# Altium schematic sheet Flash.SchDoc(Flash)
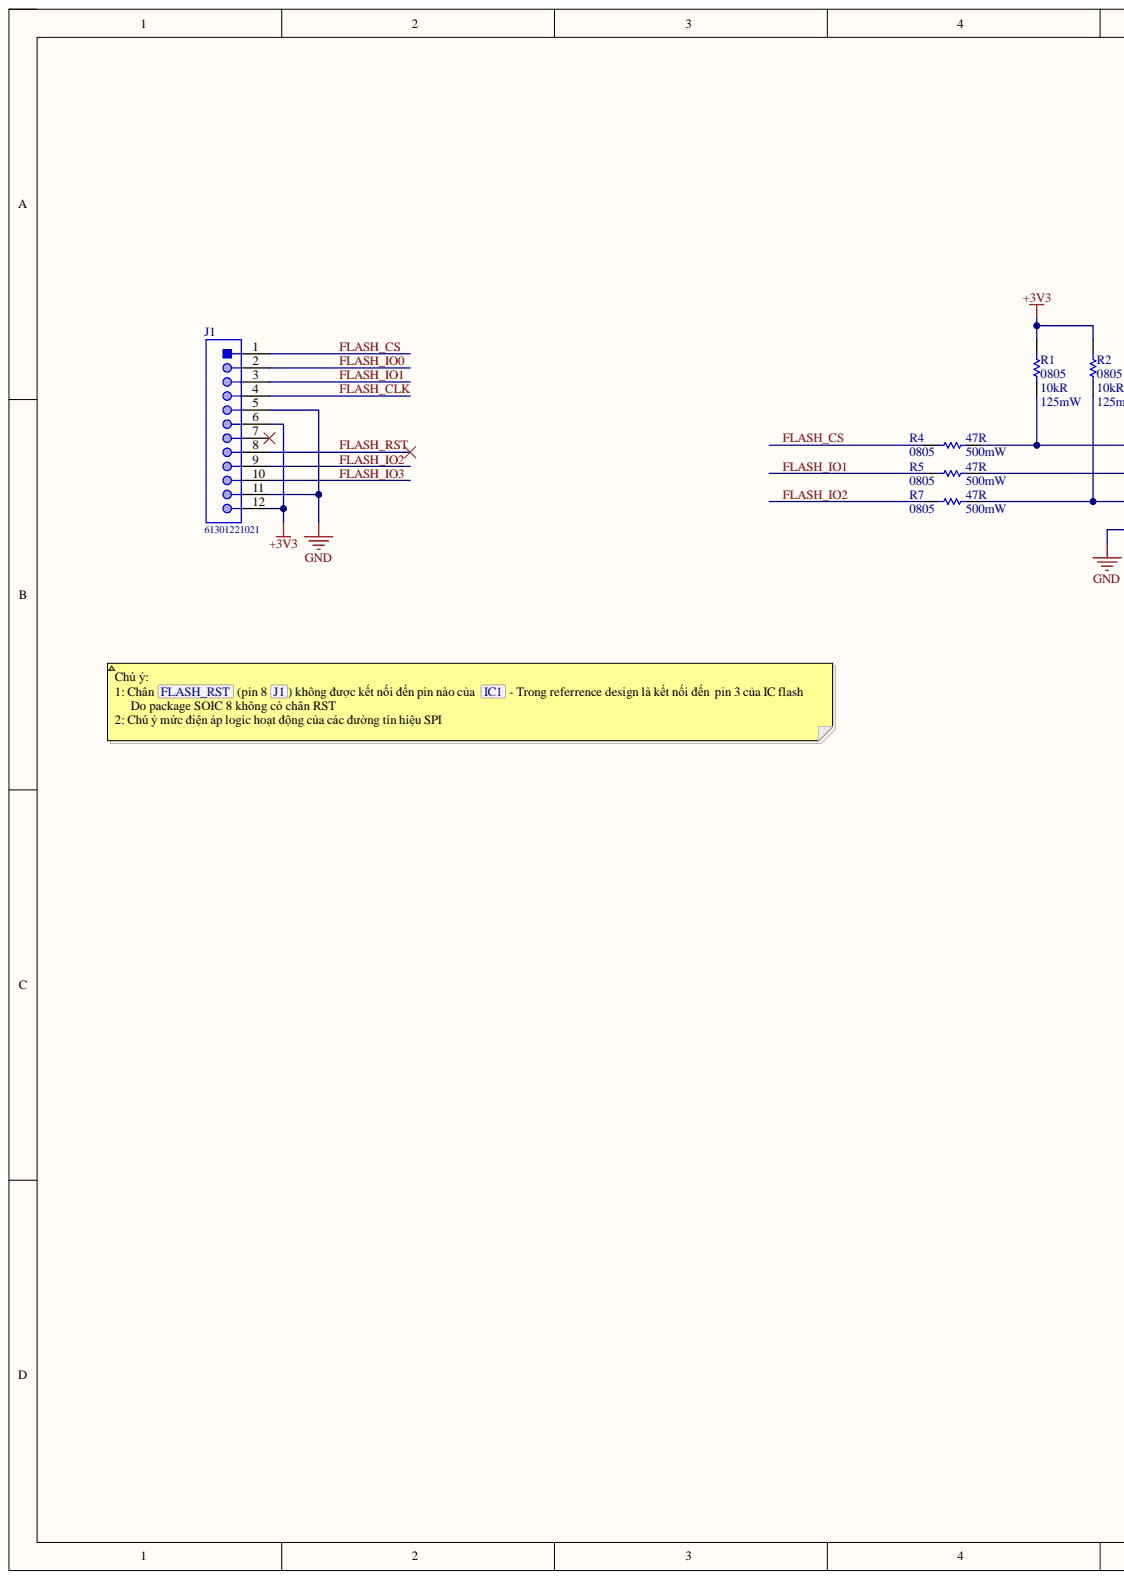
[diagram p0: Flash.SchDoc, left]
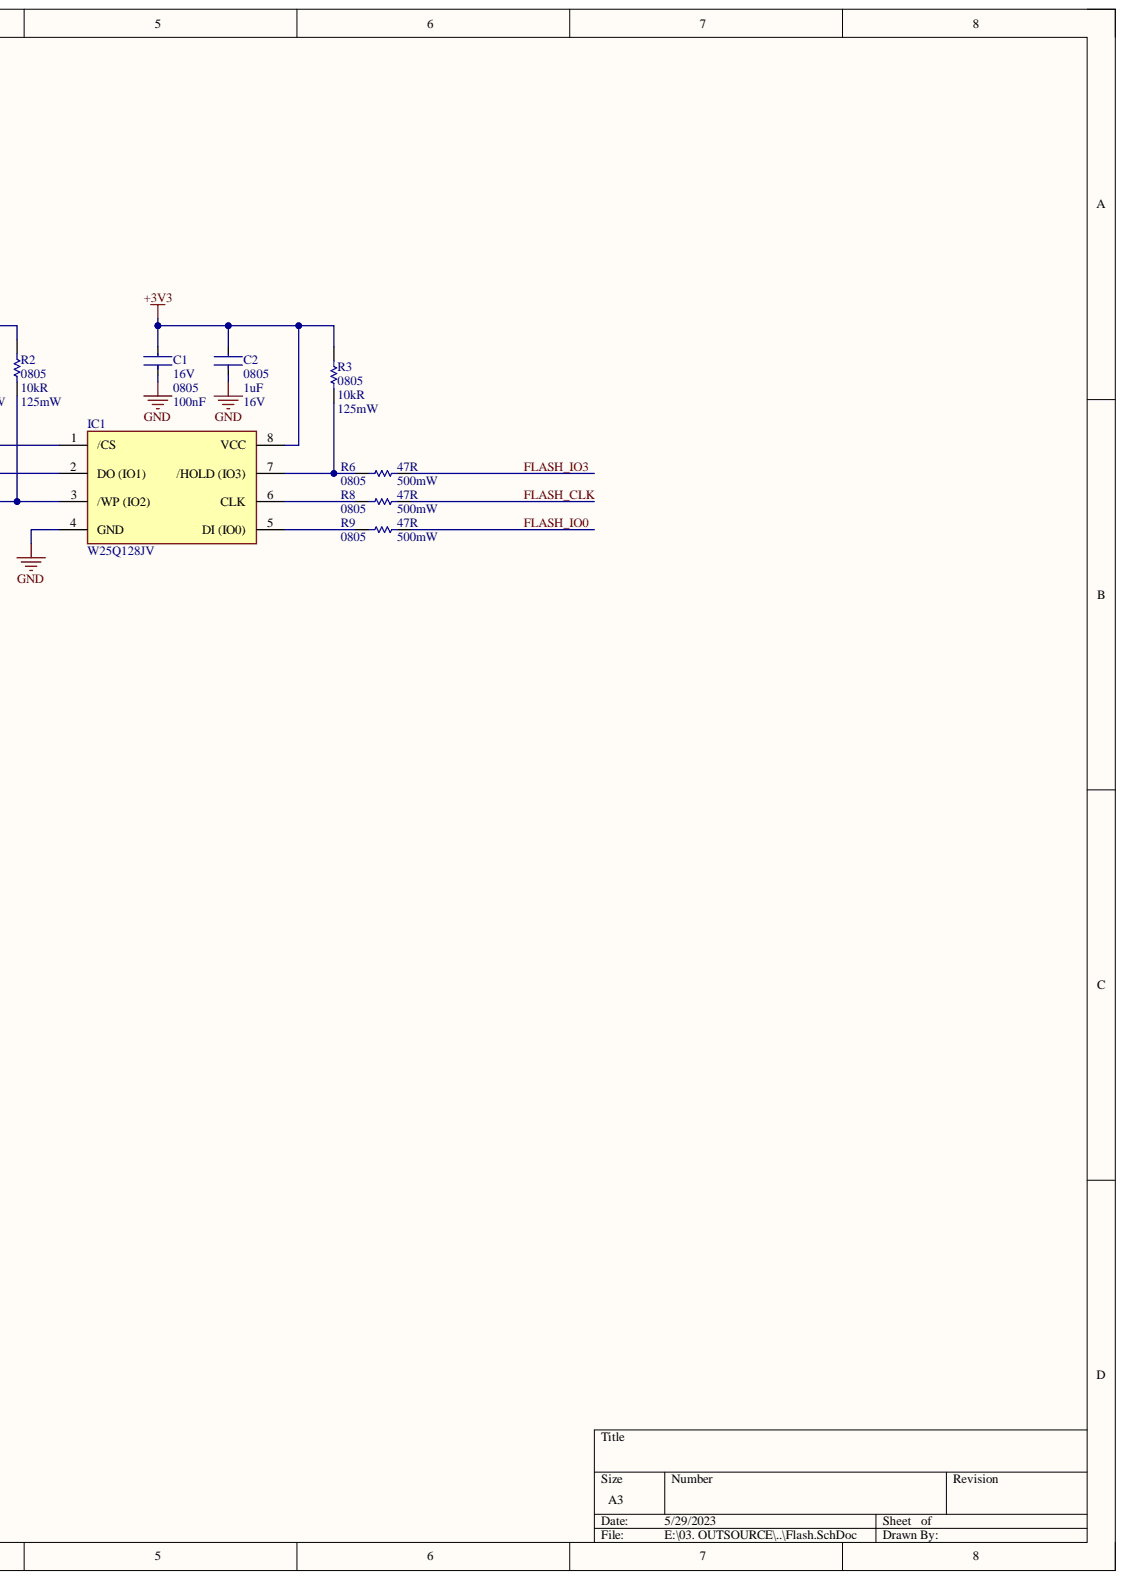
[diagram p1: Flash.SchDoc, right]

## Parts
C1  value 100nF  Capacitance: 100nF  Case Code (Imperial): 0805  Voltage Rating: 16V  [FP-0805-L_2_01_0_2-W_1_25-IPC_B]  (hidden comment: 08051C104KAT2A)
C2  value 1uF  Case Code (Imperial): 0805  Voltage: 16V  [FP-0805-L_2_01_0_2-W_1_25-IPC_B]  (hidden comment: C0805C105K4PACTU)
IC1  W25Q128JV  [SOIC127P790X216-8N]
J1  61301221021  [61301221021]
R1  value 10kR  Case Code (Imperial): 0805  Max Power Dissipation: 125mW  [RESC2013X70X40LL20T20]  (hidden comment: RC0805FR-0710KL)
R2  value 10kR  Case Code (Imperial): 0805  Max Power Dissipation: 125mW  [RESC2013X70X40LL20T20]  (hidden comment: RC0805FR-0710KL)
R3  value 10kR  Case Code (Imperial): 0805  Max Power Dissipation: 125mW  [RESC2013X70X40LL20T20]  (hidden comment: RC0805FR-0710KL)
R4  value 47R  Case Code (Imperial): 0805  Max Power Dissipation: 500mW  [RESC2013X70X40LL20T20]  (hidden comment: ERJ-P6WF47R0V)
R5  value 47R  Case Code (Imperial): 0805  Max Power Dissipation: 500mW  [RESC2013X70X40LL20T20]  (hidden comment: ERJ-P6WF47R0V)
R6  value 47R  Case Code (Imperial): 0805  Max Power Dissipation: 500mW  [RESC2013X70X40LL20T20]  (hidden comment: ERJ-P6WF47R0V)
R7  value 47R  Case Code (Imperial): 0805  Max Power Dissipation: 500mW  [RESC2013X70X40LL20T20]  (hidden comment: ERJ-P6WF47R0V)
R8  value 47R  Case Code (Imperial): 0805  Max Power Dissipation: 500mW  [RESC2013X70X40LL20T20]  (hidden comment: ERJ-P6WF47R0V)
R9  value 47R  Case Code (Imperial): 0805  Max Power Dissipation: 500mW  [RESC2013X70X40LL20T20]  (hidden comment: ERJ-P6WF47R0V)

## Nets
+3V3: C1.2 C2.2 IC1.8(VCC) J1.6 J1.12 R1.1 R2.1 R3.1
GND: C1.1 C2.1 IC1.4(GND) J1.5 J1.11
FLASH_CLK: J1.4 R8.1
FLASH_CS: J1.1 R4.2
FLASH_IO0: J1.2 R9.1
FLASH_IO1: J1.3 R5.2
FLASH_IO2: J1.9 R7.2
FLASH_IO3: J1.10 R6.1
FLASH_RST: J1.8
NetIC1_1: IC1.1(/CS) R1.2 R4.1
NetIC1_2: IC1.2(DO_(IO1)) R5.1
NetIC1_3: IC1.3(/WP_(IO2)) R2.2 R7.1
NetIC1_5: IC1.5(DI_(IO0)) R9.2
NetIC1_6: IC1.6(CLK) R8.2
NetIC1_7: IC1.7(/HOLD_(IO3)) R3.2 R6.2

Not connected: J1 7

## Library data of the parts
C1 = CMP-2007-04174-2: General Purpose Ceramic Capacitor, 0805, 100nF, 10%, X7R, 15%, 100V; Manufacturer: Kyocera AVX; Case Code (Metric): 2012; Case/Package: 0805; Category: Ceramic Capacitors; Component Kind: Standard; Construction: Flat; Contact Plating: Tin; Depth: 1.25mm; Dielectric: X7R; Dielectric Material: Ceramic; Height: 1.4mm; Lead Free: Lead Free; Length: 2.01mm; Library Name: Altium Content Vault; Manufacturer Part Number: 08051C104KAT2A; Max Operating Temperature: 125°C; Min Operating Temperature: -55°C; Mount: Surface Mount; Mounting Technology: Surface Mount,PCB; Number of Pins: 2; Package Quantity: 2000; Packaging: Tape and Reel; Pins: 2; Pricing: 1=0,14, 10=0,103, 50=0,0584, 100=0,0483, 500=0,03388, 1000=0,02805 (USD); Radiation Hardening: No; REACH SVHC: No SVHC; Reach SVHC Compliant: No; RoHS: Yes; RoHS Compliant: Yes; Series: -; Stock: 469390; Supplier: Digi-Key; Supplier Part Number: 478-5028-1-ND; Termination: SMD/SMT; Thickness: 0.055inch; Tolerance: 10%; Voltage: 100V; Voltage Rating (DC): 100V; Width: 0.049inch
C2 = CMP-2007-04174-2: General Purpose Ceramic Capacitor, 0805, 100nF, 10%, X7R, 15%, 100V; Manufacturer: KEMET; Dielectric: X5R; Dissipation Factor: 5%; Case Code (Metric): 2012; Case/Package: 0805; Category: Ceramic Capacitors; Component Kind: Standard; Construction: Flat; Depth: 1.25mm; Dielectric Material: Ceramic; Height: 1.25mm; Lead Free: Lead Free; Length: 2mm; Library Name: Altium Content Vault; Manufacturer Part Number: C0805C105K4PACTU; Max Operating Temperature: 85°C; Min Operating Temperature: -55°C; Mounting Technology: Surface Mount,PCB; Package Quantity: 2500; Packaging: Tape and Reel; Pricing: 1=0,2, 10=0,136, 50=0,0814, 100=0,0687, 500=0,047, 1000=0,04158 (USD); Radiation Hardening: No; Reach SVHC Compliant: No; RoHS: Yes; RoHS Compliant: Yes; Series: C0805C; Stock: 66614; Supplier: Digi-Key; Supplier Part Number: 399-C0805C105K4PAC7800CT-ND; Termination: SMD/SMT; Thickness: 0.055inch; Tolerance: 10%; Voltage Rating: 16V; Voltage Rating (DC): 16V; Width: 1.2mm
IC1 = W25Q128JVSIM_TR: Integrated Circuit; Manufacturer_Name: Winbond; Manufacturer_Part_Number: W25Q128JVSIM TR; Arrow Part Number: W25Q128JVSIM TR; Arrow Price/Stock: https://www.arrow.com/en/products/w25q128jvsimtr/winbond-electronics?region=nac; Component Kind: Standard; Datasheet Link: http://www.winbond.com/resource-files/w25q128jv%20dtr%20revb%2011042016.pdf; Height: 2.16mm; Mouser Part Number: 454-W25Q128JVSIMTR; Mouser Price/Stock: https://www.mouser.co.uk/ProductDetail/Winbond/W25Q128JVSIM-TR?qs=qSfuJ%252Bfl%2Fd6ji9IuruL6Zg%3D%3D; Library Name: Flash Pmod.SCHLIB
J1 = CMP-1502-01087-1: THT Angled Pin Header WR-PHD, Pitch 2.54 mm, Dual Row, 12 pins; Manufacturer: Wurth Electronics; Contact Resistance: 20mOhm; Gender: Male; Number of Contacts: 12; Pitch: 2.54mm; Rated Current: 3A; Voltage Rating: 250V; Withstanding Voltage: 500VAC; Working Voltage: 250VAC; Category: Connectors; Component Kind: Standard; ComponentLink1Description: http://www.farnell.com/cad/1737270.pdf?src-supplier=Element14; ComponentLink1URL: http://www.farnell.com/cad/1737270.pdf?src-supplier=Element14; ComponentLink2Description: https://katalog.we-online.de/em/datasheet/6130xx21021.pdf?src-supplier=Digi-Key; ComponentLink2URL: https://katalog.we-online.de/em/datasheet/6130xx21021.pdf?src-supplier=Digi-Key; Connector Type: Header; Contact Finish - Mating: GOLD; Contact Material: Copper Alloy,Copper; Contact Plating: Gold; Contact Shape: Square; Contact Type: Male Pin; Datasheet URL: https://katalog.we-online.de/em/datasheet/6130xx21021.pdf; Fastening Type: Pull; Flammability Rating: UL94 V-0; Insulation Color: Black; Insulation Material: Nylon; Manufacturer Part Number: 61301221021; Manufacturer URL: http://www.we-online.de; Max Operating Temperature: 105°C; Min Operating Temperature: -40°C; Mounting Technology: THT; Number of Positions: 12; Number of Rows: 2; Operating Temperature: -40to105degC; Orientation: Angled; Package Reference: 61301221021; Packaging: Bag; Part Number: 61301221021; Pin Number: 12; Pricing: 1=0,96, 10=0,817, 25=0,6812, 50=0,568, 100=0,516, 1000=0,469 (USD); Reach SVHC Compliant: Unknown; RoHS: Yes; RoHS Compliant: Yes; Row Number: Dual; Series: WR-PHD; Stock: 2535; Supplier: Digi-Key; Supplier Part Number: 732-5354-ND; Termination: Solder; Library Name: Flash Pmod.SCHLIB; Publisher: WurthElektronik
R1-R3 = CMP-2001-00486-1: 10 kOhms ±1% 0.125W, 1/8W Chip Resistor 0805 (2012 Metric) Moisture Resistant Thick Film; Manufacturer: Yageo; Temperature Coefficient: 100ppm/°C; Voltage Rating: 150V; Case Code (Metric): 2012; Case/Package: 0805; Category: Chip SMD Resistors; Component Kind: Standard; ComponentLink1Description: https://datasheet.ciiva.com/11808/0900766b8106beab-11808151.pdf?src-supplier=Rs; ComponentLink1URL: https://datasheet.ciiva.com/11808/0900766b8106beab-11808151.pdf?src-supplier=Rs; ComponentLink2Description: https://datasheet.ciiva.com/46447/444164-46447563.pdf?src-supplier=Element14; ComponentLink2URL: https://datasheet.ciiva.com/46447/444164-46447563.pdf?src-supplier=Element14; ComponentLink3Description: https://datasheet.ciiva.com/2456/pyu-r-int-thick-7-2456973.pdf?src-supplier=Digi-Key; ComponentLink3URL: https://datasheet.ciiva.com/2456/pyu-r-int-thick-7-2456973.pdf?src-supplier=Digi-Key; ComponentLink4Description: https://datasheet.ciiva.com/2456/pyu-r-int-thick-7-2456974.pdf?src-supplier=Digi-Key; ComponentLink4URL: https://datasheet.ciiva.com/2456/pyu-r-int-thick-7-2456974.pdf?src-supplier=Digi-Key; ComponentLink5Description: https://datasheet.ciiva.com/2456/pyu-r-int-thick-7-2456972.pdf?src-supplier=Digi-Key; ComponentLink5URL: https://datasheet.ciiva.com/2456/pyu-r-int-thick-7-2456972.pdf?src-supplier=Digi-Key; ComponentLink6Description: https://datasheet.ciiva.com/12669/rc0805-12669688.pdf?src-supplier=Mouser; ComponentLink6URL: https://datasheet.ciiva.com/12669/rc0805-12669688.pdf?src-supplier=Mouser; ComponentLink7Description: https://datasheet.ciiva.com/16747/rc0805-16747087.pdf?src-supplier=Future+Electronics; ComponentLink7URL: https://datasheet.ciiva.com/16747/rc0805-16747087.pdf?src-supplier=Future+Electronics; Construction: Rectangular; Depth: 1.25mm; Features: Moisture Resistant; Height: 0.024inch; Lead Free: Lead Free; Length: 0.079inch; Library Name: Altium Content Vault; Manufacturer Part Number: RC0805FR-0710KL; Max Operating Temperature: 155°C; Military Standard: Not; Min Operating Temperature: -55°C; Number of Terminations: 2; Package Quantity: 1; Packaging: Tape and Reel; Power Rating: 125mW; Pricing: 1=0,1, 10=0,027, 50=0,017, 100=0,0143, 500=0,00986, 1000=0,00852 (USD); Reach SVHC Compliant: Unknown; Resistance Tolerance: 1%; Resistor Type: Thick Film; RoHS: Yes; RoHS Compliant: Yes; Series: RC; Stock: 9862859; Supplier: Digi-Key; Supplier Part Number: 311-10.0KCRCT-ND; Technology: THICK FILM; Termination: SMD/SMT; Tolerance: 1%; Width: 0.049inch
R4-R9 = CMP-2001-00486-1: 47 Ohms ±1% 0.5W, 1/2W Chip Resistor 0805 (2012 Metric) Automotive AEC-Q200, Pulse Withstanding Thick Film; Manufacturer: Panasonic; Temperature Coefficient: 200ppm/°C; Voltage Rating: 150V; Case Code (Metric): 2012; Case/Package: 0805; Category: Chip SMD Resistors; Component Kind: Standard; ComponentLink1Description: https://datasheet.ciiva.com/48536/erjp-series-eng-tds-48536489.pdf?src-supplier=Distrelec; ComponentLink1URL: https://datasheet.ciiva.com/48536/erjp-series-eng-tds-48536489.pdf?src-supplier=Distrelec; ComponentLink2Description: https://datasheet.ciiva.com/6082/1790600-6082415.pdf?src-supplier=Farnell; ComponentLink2URL: https://datasheet.ciiva.com/6082/1790600-6082415.pdf?src-supplier=Farnell; ComponentLink3Description: https://datasheet.ciiva.com/7886/1790600-7886918.pdf?src-supplier=Element14; ComponentLink3URL: https://datasheet.ciiva.com/7886/1790600-7886918.pdf?src-supplier=Element14; ComponentLink4Description: https://datasheet.ciiva.com/48531/aoa0000ce4-48531642.pdf?src-supplier=Avnet; ComponentLink4URL: https://datasheet.ciiva.com/48531/aoa0000ce4-48531642.pdf?src-supplier=Avnet; Depth: 1.25mm; Features: Automotive AEC-Q200; Height: 0.65mm; Length: 2mm; Library Name: Altium Content Vault; Manufacturer Part Number: ERJ-P6WF47R0V; Max Operating Temperature: 155°C; Min Operating Temperature: -55°C; Number of Terminations: 2; Packaging: Cut Tape; Power Rating: 500mW; Pricing: 1=0,57, 10=0,438, 50=0,2474, 100=0,184, 500=0,13326, 1000=0,10153 (USD); Ratings: AEC-Q200; Reach SVHC Compliant: No; Resistance Tolerance: 1%; Resistor Type: Thick Film; RoHS: Yes; RoHS Compliant: Yes; Series: ERJ; Stock: 32493; Supplier: Digi-Key; Supplier Part Number: P16869CT-ND; Technology: THICK FILM; Termination: Flat; Tolerance: 1%; Voltage Rating (DC): 150V
